# Altium schematic sheet [06] - CAMERA AND RPI.SchDoc("[06] - CAMERA AND RPI")
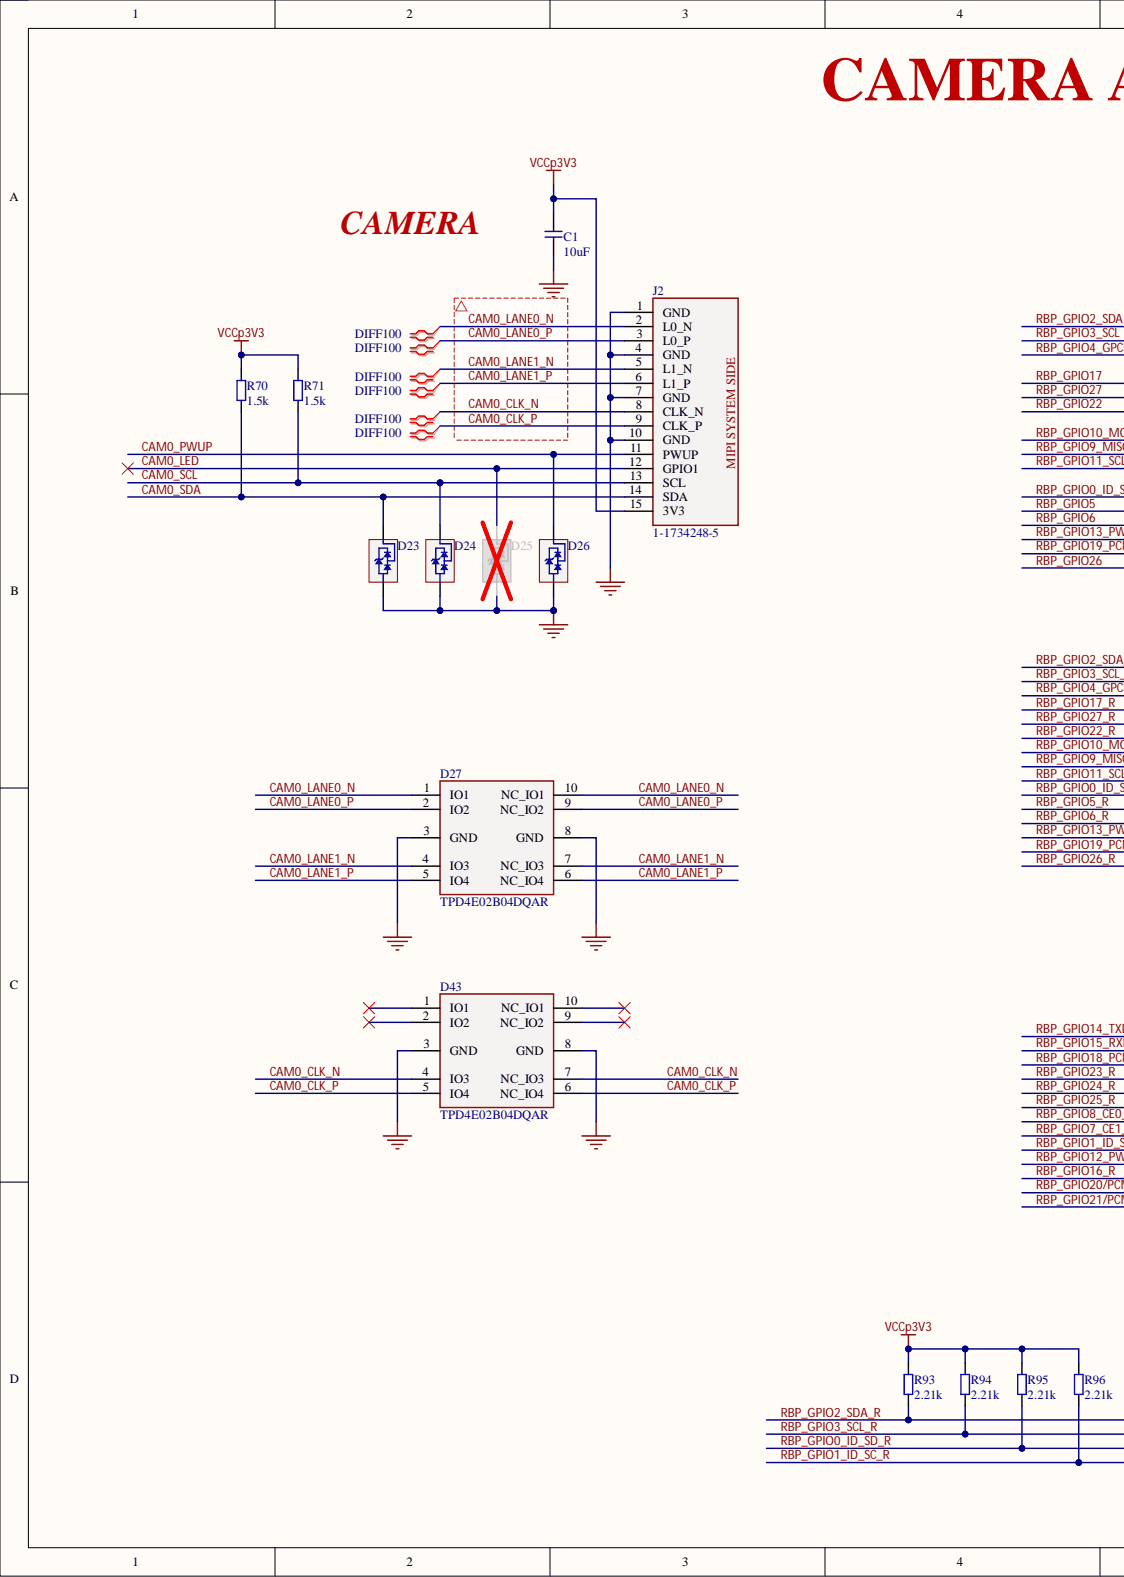
[diagram p0: [06] - CAMERA AND RPI.SchDoc, left]
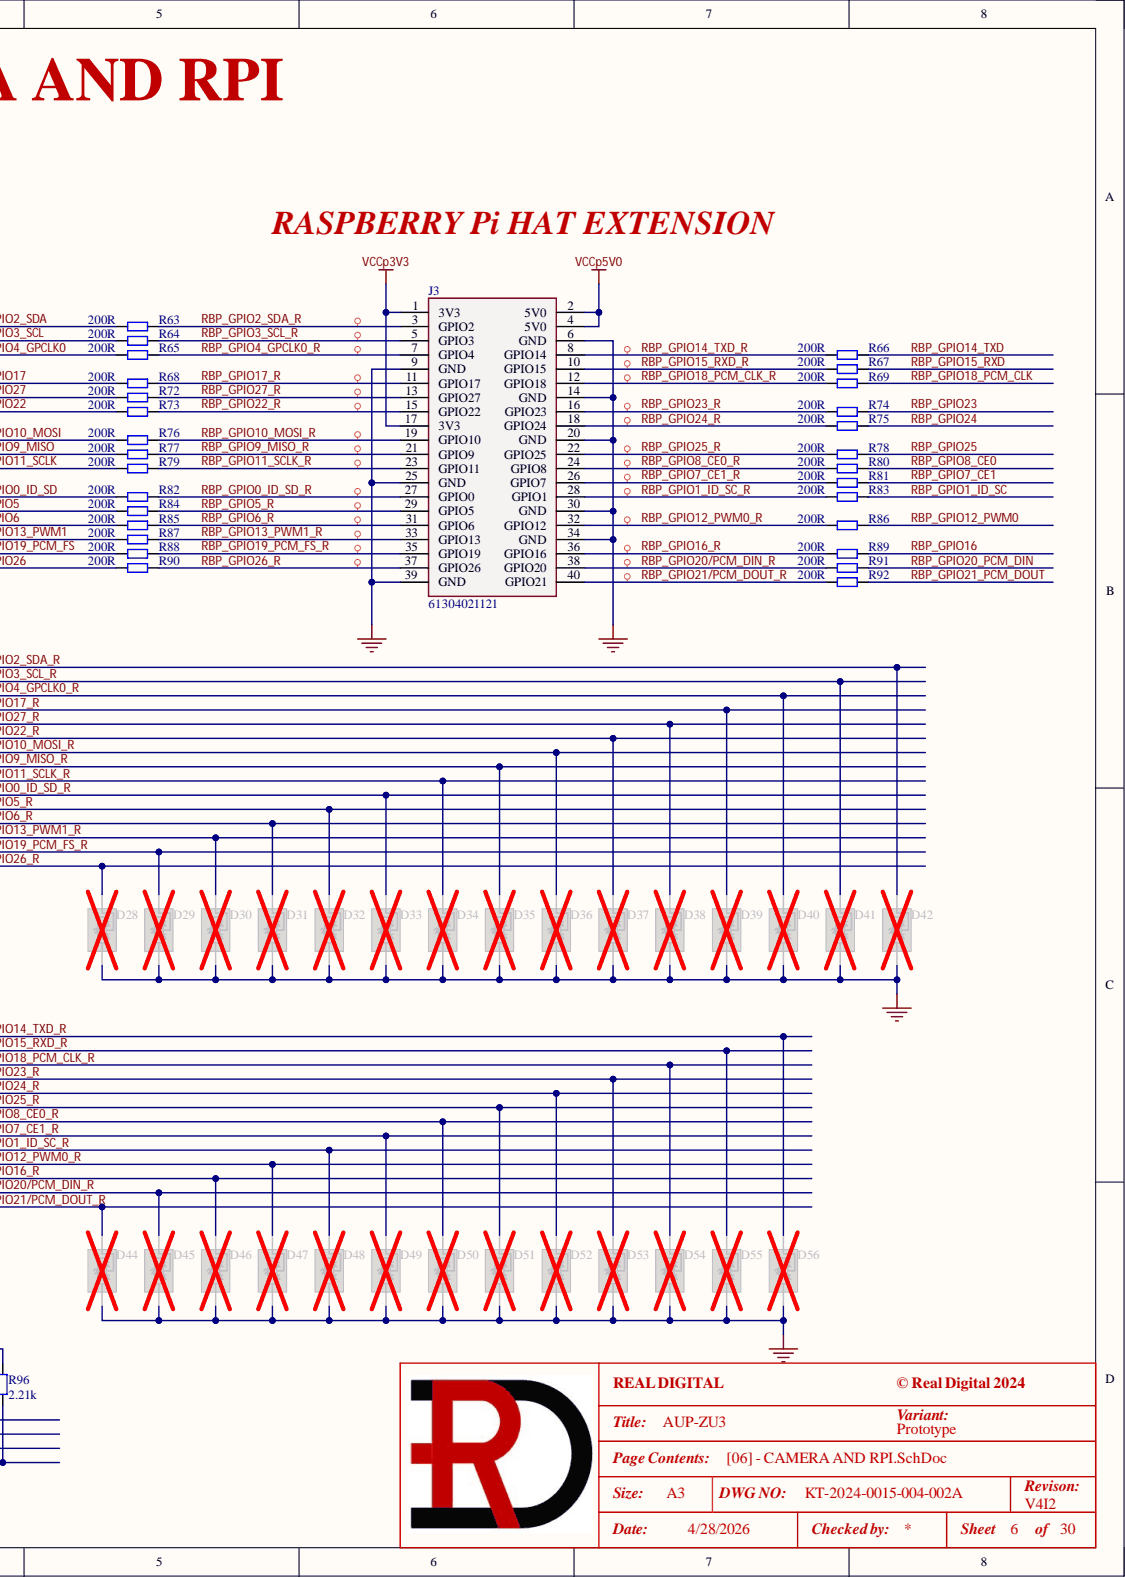
[diagram p1: [06] - CAMERA AND RPI.SchDoc, right]

## Parts
C1  10uF  [C0603]
D23  TPD1E05U06DPYR  [DPY0002A - TI]  (value not drawn)
D24  TPD1E05U06DPYR  [DPY0002A - TI]  (value not drawn)
D25  TPD1E05U06DPYR  [DPY0002A - TI]  (value not drawn)
D26  TPD1E05U06DPYR  [DPY0002A - TI]  (value not drawn)
D27  TPD4E02B04DQAR  [DQA0010A_UFDFN10 - TI]
D28  TPD1E05U06DPYR  [DPY0002A - TI]  (value not drawn)
D29  TPD1E05U06DPYR  [DPY0002A - TI]  (value not drawn)
D30  TPD1E05U06DPYR  [DPY0002A - TI]  (value not drawn)
D31  TPD1E05U06DPYR  [DPY0002A - TI]  (value not drawn)
D32  TPD1E05U06DPYR  [DPY0002A - TI]  (value not drawn)
D33  TPD1E05U06DPYR  [DPY0002A - TI]  (value not drawn)
D34  TPD1E05U06DPYR  [DPY0002A - TI]  (value not drawn)
D35  TPD1E05U06DPYR  [DPY0002A - TI]  (value not drawn)
D36  TPD1E05U06DPYR  [DPY0002A - TI]  (value not drawn)
D37  TPD1E05U06DPYR  [DPY0002A - TI]  (value not drawn)
D38  TPD1E05U06DPYR  [DPY0002A - TI]  (value not drawn)
D39  TPD1E05U06DPYR  [DPY0002A - TI]  (value not drawn)
D40  TPD1E05U06DPYR  [DPY0002A - TI]  (value not drawn)
D41  TPD1E05U06DPYR  [DPY0002A - TI]  (value not drawn)
D42  TPD1E05U06DPYR  [DPY0002A - TI]  (value not drawn)
D43  TPD4E02B04DQAR  [DQA0010A_UFDFN10 - TI]
D44  TPD1E05U06DPYR  [DPY0002A - TI]  (value not drawn)
D45  TPD1E05U06DPYR  [DPY0002A - TI]  (value not drawn)
D46  TPD1E05U06DPYR  [DPY0002A - TI]  (value not drawn)
D47  TPD1E05U06DPYR  [DPY0002A - TI]  (value not drawn)
D48  TPD1E05U06DPYR  [DPY0002A - TI]  (value not drawn)
D49  TPD1E05U06DPYR  [DPY0002A - TI]  (value not drawn)
D50  TPD1E05U06DPYR  [DPY0002A - TI]  (value not drawn)
D51  TPD1E05U06DPYR  [DPY0002A - TI]  (value not drawn)
D52  TPD1E05U06DPYR  [DPY0002A - TI]  (value not drawn)
D53  TPD1E05U06DPYR  [DPY0002A - TI]  (value not drawn)
D54  TPD1E05U06DPYR  [DPY0002A - TI]  (value not drawn)
D55  TPD1E05U06DPYR  [DPY0002A - TI]  (value not drawn)
D56  TPD1E05U06DPYR  [DPY0002A - TI]  (value not drawn)
J2  1-1734248-5  [1-1734248-5]
J3  61304021121  [HDR2X20]
R63  200R  [R0402]
R64  200R  [R0402]
R65  200R  [R0402]
R66  200R  [R0402]
R67  200R  [R0402]
R68  200R  [R0402]
R69  200R  [R0402]
R70  1.5k  [R0402]
R71  1.5k  [R0402]
R72  200R  [R0402]
R73  200R  [R0402]
R74  200R  [R0402]
R75  200R  [R0402]
R76  200R  [R0402]
R77  200R  [R0402]
R78  200R  [R0402]
R79  200R  [R0402]
R80  200R  [R0402]
R81  200R  [R0402]
R82  200R  [R0402]
R83  200R  [R0402]
R84  200R  [R0402]
R85  200R  [R0402]
R86  200R  [R0402]
R87  200R  [R0402]
R88  200R  [R0402]
R89  200R  [R0402]
R90  200R  [R0402]
R91  200R  [R0402]
R92  200R  [R0402]
R93  2.21k  [R0402]
R94  2.21k  [R0402]
R95  2.21k  [R0402]
R96  2.21k  [R0402]

## Nets
GND: C1.1 D23.2 D24.2 D25.2 D26.2 D27.3(GND) D27.8(GND) D28.2 D29.2 D30.2 D31.2 D32.2 D33.2 D34.2 D35.2 D36.2 D37.2 D38.2 D39.2 D40.2 D41.2 D42.2 D43.3(GND) D43.8(GND) D44.2 D45.2 D46.2 D47.2 D48.2 D49.2 D50.2 D51.2 D52.2 D53.2 D54.2 D55.2 D56.2 J2.1(GND) J2.4(GND) J2.7(GND) J2.10(GND) J3.6(GND) J3.9(GND) J3.14(GND) J3.20(GND) J3.25(GND) J3.30(GND) J3.34(GND) J3.39(GND)
VCCp3V3: C1.2 J2.15(3V3) J3.1(3V3) J3.17(3V3) R70.2 R71.2 R93.2 R94.2 R95.2 R96.2
VCCp5V0: J3.2(5V0) J3.4(5V0)
CAM0_CLK_N: D43.4(IO3) D43.7(NC_IO3) J2.8(CLK_N)
CAM0_CLK_P: D43.5(IO4) D43.6(NC_IO4) J2.9(CLK_P)
CAM0_LANE0_N: D27.1(IO1) D27.10(NC_IO1) J2.2(L0_N)
CAM0_LANE0_P: D27.2(IO2) D27.9(NC_IO2) J2.3(L0_P)
CAM0_LANE1_N: D27.4(IO3) D27.7(NC_IO3) J2.5(L1_N)
CAM0_LANE1_P: D27.5(IO4) D27.6(NC_IO4) J2.6(L1_P)
CAM0_LED: D25.1 J2.12(GPIO1)
CAM0_PWUP: D26.1 J2.11(PWUP)
CAM0_SCL: D24.1 J2.13(SCL) R71.1
CAM0_SDA: D23.1 J2.14(SDA) R70.1
RBP_GPIO0_ID_SD: R82.1
RBP_GPIO0_ID_SD_R: D33.1 J3.27(GPIO0) R82.2 R95.1
RBP_GPIO1_ID_SC: R83.1
RBP_GPIO1_ID_SC_R: D48.1 J3.28(GPIO1) R83.2 R96.1
RBP_GPIO2_SDA: R63.1
RBP_GPIO2_SDA_R: D42.1 J3.3(GPIO2) R63.2 R93.1
RBP_GPIO3_SCL: R64.1
RBP_GPIO3_SCL_R: D41.1 J3.5(GPIO3) R64.2 R94.1
RBP_GPIO4_GPCLK0: R65.1
RBP_GPIO4_GPCLK0_R: D40.1 J3.7(GPIO4) R65.2
RBP_GPIO5: R84.1
RBP_GPIO5_R: D32.1 J3.29(GPIO5) R84.2
RBP_GPIO6: R85.1
RBP_GPIO6_R: D31.1 J3.31(GPIO6) R85.2
RBP_GPIO7_CE1: R81.1
RBP_GPIO7_CE1_R: D49.1 J3.26(GPIO7) R81.2
RBP_GPIO8_CE0: R80.1
RBP_GPIO8_CE0_R: D50.1 J3.24(GPIO8) R80.2
RBP_GPIO9_MISO: R77.1
RBP_GPIO9_MISO_R: D35.1 J3.21(GPIO9) R77.2
RBP_GPIO10_MOSI: R76.1
RBP_GPIO10_MOSI_R: D36.1 J3.19(GPIO10) R76.2
RBP_GPIO11_SCLK: R79.1
RBP_GPIO11_SCLK_R: D34.1 J3.23(GPIO11) R79.2
RBP_GPIO12_PWM0: R86.1
RBP_GPIO12_PWM0_R: D47.1 J3.32(GPIO12) R86.2
RBP_GPIO13_PWM1: R87.1
RBP_GPIO13_PWM1_R: D30.1 J3.33(GPIO13) R87.2
RBP_GPIO14_TXD: R66.1
RBP_GPIO14_TXD_R: D56.1 J3.8(GPIO14) R66.2
RBP_GPIO15_RXD: R67.1
RBP_GPIO15_RXD_R: D55.1 J3.10(GPIO15) R67.2
RBP_GPIO16: R89.1
RBP_GPIO16_R: D46.1 J3.36(GPIO16) R89.2
RBP_GPIO17: R68.1
RBP_GPIO17_R: D39.1 J3.11(GPIO17) R68.2
RBP_GPIO18_PCM_CLK: R69.1
RBP_GPIO18_PCM_CLK_R: D54.1 J3.12(GPIO18) R69.2
RBP_GPIO19_PCM_FS: R88.1
RBP_GPIO19_PCM_FS_R: D29.1 J3.35(GPIO19) R88.2
RBP_GPIO20/PCM_DIN_R: D45.1 J3.38(GPIO20) R91.2
RBP_GPIO20_PCM_DIN: R91.1
RBP_GPIO21/PCM_DOUT_R: D44.1 J3.40(GPIO21) R92.2
RBP_GPIO21_PCM_DOUT: R92.1
RBP_GPIO22: R73.1
RBP_GPIO22_R: D37.1 J3.15(GPIO22) R73.2
RBP_GPIO23: R74.1
RBP_GPIO23_R: D53.1 J3.16(GPIO23) R74.2
RBP_GPIO24: R75.1
RBP_GPIO24_R: D52.1 J3.18(GPIO24) R75.2
RBP_GPIO25: R78.1
RBP_GPIO25_R: D51.1 J3.22(GPIO25) R78.2
RBP_GPIO26: R90.1
RBP_GPIO26_R: D28.1 J3.37(GPIO26) R90.2
RBP_GPIO27: R72.1
RBP_GPIO27_R: D38.1 J3.13(GPIO27) R72.2

Not connected: D43 1(IO1) 2(IO2) 9(NC_IO2) 10(NC_IO1)

## Library data of the parts
C1 = GRM188R6YA106MA73D: CAP CER 10uF 35V X5R 0603; Manufacturer 1: Murata Electronics; .Checked_PCB: RV; C P/N: CY-111-0645; LoadStatus: Load; Tolerance: 20%; Category: Capacitors; Component Kind: Standard; Library Name: KT-RealDigital.IntLib; Manufacturer 1 Part Number: GRM188R6YA106MA73D; Temperature Coefficient: X5R; Voltage - Rated: 35V; .Checked_SCH: RV; .Creator: RV
D23-D26 D28-D42 D44-D56 = TPD1E05U06DPYR: TVS DIODE 5.5VWM 14VC 2X1SON; Manufacturer 1: Texas Instruments; .Checked_PCB: RV; C P/N: RD-121-0534; LoadStatus: Load; Category: TVS - Diodes; Component Kind: Standard; Library Name: KT-RealDigital.IntLib; Manufacturer 1 Part Number: TPD1E05U06DPYR; .Checked_SCH: RV; .Creator: RV
D27 D43 = TPD4E02B04DQAR: TVS DIODE 3.6V 8.8V 10USON; Manufacturer 1: Texas Instruments; .Checked_PCB: RV; C P/N: 121-0517; LoadStatus: Load; Category: TVS - Diodes; Component Kind: Standard; Library Name: KT-RealDigital.IntLib; Manufacturer 1 Part Number: TPD4E02B04DQAR; .Checked_SCH: RV; .Creator: RV
J2 = 1-1734248-5-MIPI: MIPI CONN FPC VERT 15POS 1MM SMD; Manufacturer 1: TE Connectivity AMP Connectors; .Checked_PCB: RV; .Creator: DG; C P/N: 161-0096; LoadStatus: Load; Category: Connectors; Component Kind: Standard; Library Name: KT-RealDigital.IntLib; Manufacturer 1 Part Number: 1-1734248-5; .Checked_SCH: RV
J3 = RASPBERRYPIE_EXTENSION: Header 2.54mm, 2x20; Manufacturer 1: Würth Elektronik; .Checked_PCB: RV; .Creator: DG; C P/N: RD-163-0066; LoadStatus: Load; Category: Header; Component Kind: Standard; Library Name: KT-RealDigital.IntLib; Manufacturer 1 Part Number: 61304021121; .Checked_SCH: RV
R63-R69 R72-R92 = RC0402JR-07200RL: RES SMD 200 OHM 5% 1/16W 0402; Manufacturer 1: Yageo; .Checked_PCB: AC; .Creator: RV; C P/N: 101-0045; LoadStatus: Load; Tolerance: 5%/TK100; Category: Resistors; Component Kind: Standard; Library Name: KT-RealDigital.IntLib; Manufacturer 1 Part Number: RC0402JR-07200RL; .Checked_SCH: AC
R70 R71 = RC0402FR-071K5L: RES SMD 1.5K OHM 1% 1/16W 0402; Manufacturer 1: YAGEO; .Checked_PCB: RV; C P/N: RD-101-0082; LoadStatus: Load; Tolerance: 1%/TK100; Category: Resistors; Component Kind: Standard; Library Name: KT-RealDigital.IntLib; Manufacturer 1 Part Number: RC0402FR-071K5L; .Checked_SCH: RV; .Creator: RV
R93-R96 = RC0402FR-072K21L: RES SMD 2.21K OHM 1% 1/16W 0402; Manufacturer 1: YAGEO; .Checked_PCB: RV; C P/N: RD-101-0019; LoadStatus: Load; Tolerance: 1%/TK100; Category: Resistors; Component Kind: Standard; Library Name: KT-RealDigital.IntLib; Manufacturer 1 Part Number: RC0402FR-072K21L; .Checked_SCH: RV; .Creator: RV
